# Altium schematic sheet Conn.SchDoc(Conn)
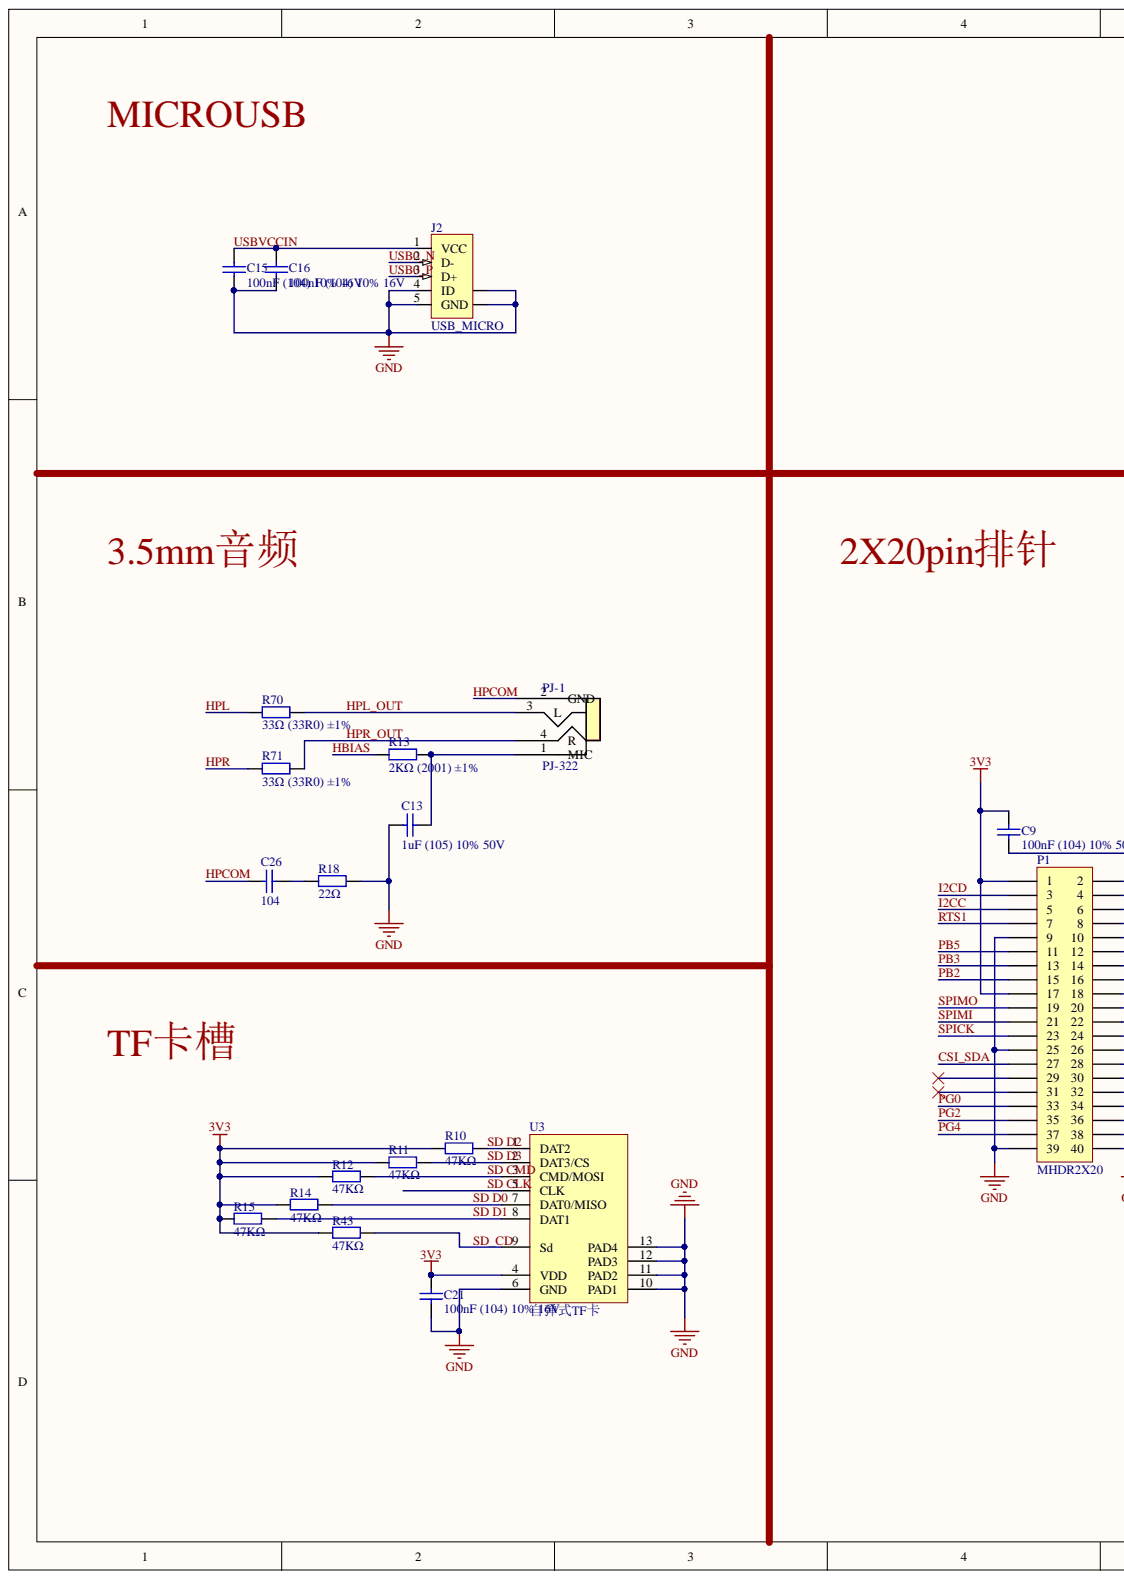
[diagram p0: Conn.SchDoc, left]
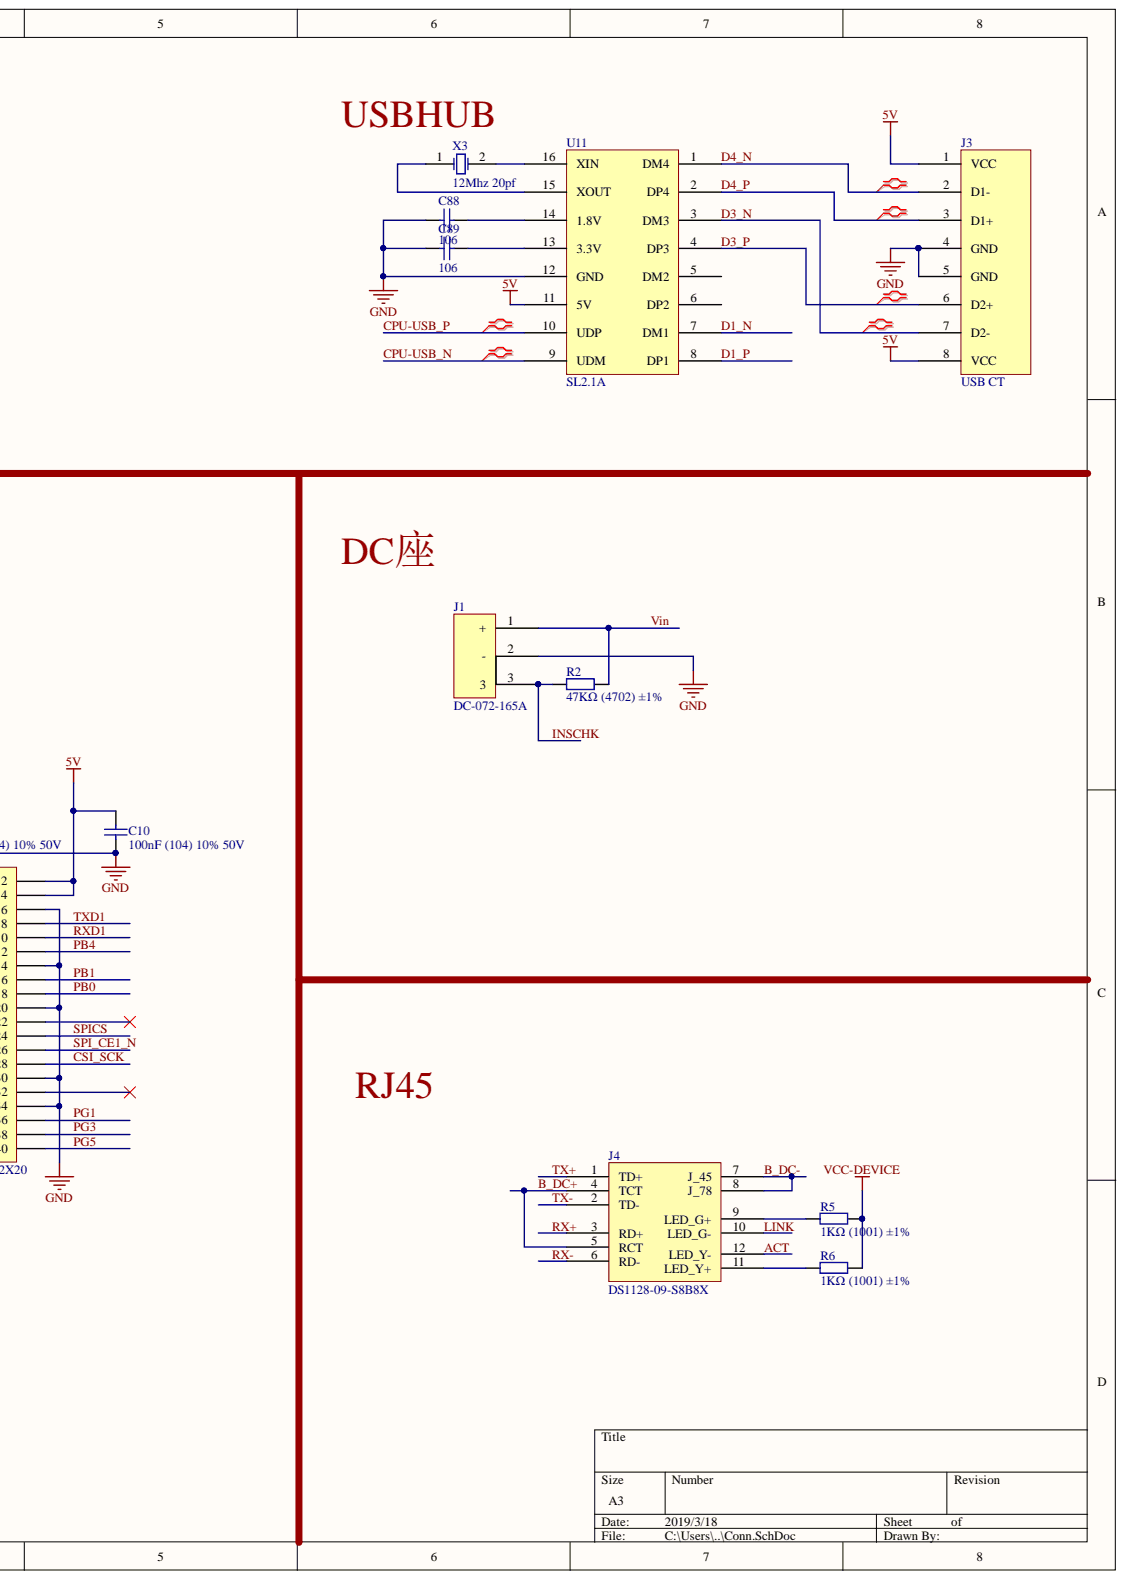
[diagram p1: Conn.SchDoc, right]

## Parts
C9  100nF (104) 10% 50V  [0402_C]
C10  100nF (104) 10% 50V  [0402_C]
C13  1uF (105) 10% 50V  [0603_C]
C15  100nF (104) 10% 16V  [0402_C]
C16  100nF (104) 10% 16V  [0402_C]
C21  100nF (104) 10% 16V  [0402_C]
C26  104  [0402_C]
C88  106  [0402_C]
C89  106  [0402_C]
J1  DC-072-165A  [DC-072-165A]
J2  USB_MICRO  [USB_MICRO1]
J3  USB CT  [USB AF]
J4  DS1128-09-S8B8X  [HR911105A]
P1  MHDR2X20  [HDR2X20]
PJ-1  PJ-322  [PJ322]
R2  47KΩ (4702) ±1%  [0402_R]
R5  1KΩ (1001) ±1%  [0402_R]
R6  1KΩ (1001) ±1%  [0402_R]
R10  47KΩ  [0402_R]
R11  47KΩ  [0402_R]
R12  47KΩ  [0402_R]
R13  2KΩ (2001) ±1%  [0402_R]
R14  47KΩ  [0402_R]
R15  47KΩ  [0402_R]
R18  22Ω  [0402_R]
R43  47KΩ  [0402_R]
R70  33Ω (33R0) ±1%  [0603_R]
R71  33Ω (33R0) ±1%  [0603_R]
U3  自弹式TF卡  [TF_self-eject N]
U11  SL2.1A  [SOP-16_150mil]
X3  12Mhz 20pf  [SMD-5032_2P]

## Nets
3V3: C9.2 C21.2 P1.1 P1.17 R10.1 R11.2 R12.2 R14.2 R15.2 R43.2 U3.4(VDD)
5V: C10.2 J3.1(VCC) J3.8(VCC) P1.2 P1.4 U11.11(5V)
GND: C9.1 C10.1 C13.1 C15.1 C16.1 C21.1 C88.1 C89.1 J1.2 J2.4(ID) J2.5 J2.6(GND) J2.7 J3.4(GND) J3.5(GND) P1.6 P1.9 P1.14 P1.20 P1.25 P1.30 P1.34 P1.39 R18.2 U3.6(GND) U3.10(PAD1) U3.11(PAD2) U3.12(PAD3) U3.13(PAD4) U11.12(GND)
VCC-DEVICE: R5.2 R6.2
ACT: J4.12(LED_Y-)
B_DC+: J4.4(TCT) J4.5(RCT)
B_DC-: J4.7(J_45) J4.8(J_78)
CPU-USB_N: U11.9(UDM)
CPU-USB_P: U11.10(UDP)
CSI_SCK: P1.28
CSI_SDA: P1.27
D1_N: U11.7(DM1)
D1_P: U11.8(DP1)
D3_N: J3.7(D2-) U11.3(DM3)
D3_P: J3.6(D2+) U11.4(DP3)
D4_N: J3.2(D1-) U11.1(DM4)
D4_P: J3.3(D1+) U11.2(DP4)
HBIAS: R13.1
HPCOM: C26.2 PJ-1.2(GND)
HPL: R70.1
HPL_OUT: PJ-1.3(L) R70.2
HPR: R71.1
HPR_OUT: PJ-1.4(R) R71.2
I2CC: P1.5
I2CD: P1.3
INSCHK: J1.3 R2.2
LINK: J4.10(LED_G-)
PB0: P1.18
PB1: P1.16
PB2: P1.15
PB3: P1.13
PB4: P1.12
PB5: P1.11
PG0: P1.33
PG1: P1.36
PG2: P1.35
PG3: P1.38
PG4: P1.37
PG5: P1.40
RTS1: P1.7
RX+: J4.3(RD+)
RX-: J4.6(RD-)
RXD1: P1.10
SD CLK: U3.5(CLK)
SD CMD: R12.1 U3.3(CMD/MOSI)
SD D0: R14.1 U3.7(DAT0/MISO)
SD D1: R15.1 U3.8(DAT1)
SD D2: R10.2 U3.1(DAT2)
SD D3: R11.1 U3.2(DAT3/CS)
SD_CD: R43.1 U3.9(Sd)
SPI_CE1_N: P1.26
SPICK: P1.23
SPICS: P1.24
SPIMI: P1.21
SPIMO: P1.19
TX+: J4.1(TD+)
TX-: J4.2(TD-)
TXD1: P1.8
USB0_N: J2.2(D-)
USB0_P: J2.3(D+)
USBVCCIN: C15.2 C16.2 J2.1(VCC)
Vin: J1.1 R2.1
NetC13_2: C13.2 PJ-1.1(MIC) R13.2
NetC26_1: C26.1 R18.1
NetC88_2: C88.2 U11.14(1.8V)
NetC89_2: C89.2 U11.13(3.3V)
NetJ4_9: J4.9(LED_G+) R5.1
NetJ4_11: J4.11(LED_Y+) R6.1
NetU11_15: U11.15(XOUT) X3.1
NetU11_16: U11.16(XIN) X3.2

Not connected: P1 22 29 31 32; U11 5(DM2) 6(DP2)

## Library data of the parts
C9 C10 C26 = 0603 100nF (104) 10% 50V: 贴片电容; SuppliersPartNumber: C14663; Supplier: LC; Package: 0603; Library Name: Cap.SchLib; ComponentLink1URL: http://www.szlcsc.com/product/details_15331.html; ComponentLink1Description: 供应商链接; Component Kind: Standard
C13 = 0603 1uF (105) 10% 50V: 贴片电容; SuppliersPartNumber: C15849; Supplier: LC; Package: 0603; Library Name: Miscellaneous Devices LC.IntLib; ComponentLink1URL: http://www.szlcsc.com/product/details_16531.html; ComponentLink1Description: 供应商链接; Component Kind: Standard
C15 C16 C21 = 0402 100nF (104) 10% 16V: 贴片电容; SuppliersPartNumber: C1525; Supplier: LC; Package: 0402; Library Name: Miscellaneous Devices LC.IntLib; ComponentLink1URL: http://www.szlcsc.com/product/details_1877.html; ComponentLink1Description: 供应商链接; Component Kind: Standard
C88 C89 = 0402 10uF (106) 20% 6.3V: 贴片电容; SuppliersPartNumber: C15525; Supplier: LC; Package: 0402; Library Name: Miscellaneous Devices LC.IntLib; ComponentLink1URL: http://www.szlcsc.com/product/details_16204.html; ComponentLink1Description: 供应商链接; Component Kind: Standard
J1 = DC-072-165A: C16214; Library Name: V3SDV.SchLib; Component Kind: Standard
J2 = USB_MICRO: C10418; Library Name: Zero.IntLib; Component Kind: Standard
J3 = USB CT: C39470; Library Name: V3SDV.SchLib; Component Kind: Standard
J4 = HLJ-6115ANL: C86578; Library Name: SmallBox.SCHLIB; Component Kind: Standard
P1 = MHDR2X20: Header, 20-Pin, Dual row; Signal Integrity: Connector; Library Name: Miscellaneous Connectors.IntLib; LatestRevisionNote: Re-released for DXP Platform.; LatestRevisionDate: 17-Jul-2002; Component Kind: Standard; Publisher: Altium Limited
PJ-1 = PJ-322: 3.5mm音频连接器; Library Name: V3SDV.SchLib; Component Kind: Standard
R2 R10-R12 R14 R15 R43 = 0402 47KΩ (4702) 1%: 贴片电阻; SuppliersPartNumber: C25792; Supplier: LC; Package: 0402; Library Name: Miscellaneous Devices LC.IntLib; ComponentLink1URL: http://www.szlcsc.com/product/details_26535.html; ComponentLink1Description: 供应商链接; Component Kind: Standard
R5 R6 = 0603 1KΩ (1001) 1%: 贴片电阻; SuppliersPartNumber: C21190; Supplier: LC; Package: 0603; Library Name: RES.SchLib; ComponentLink1URL: http://www.szlcsc.com/product/details_21904.html; ComponentLink1Description: 供应商链接; Component Kind: Standard
R13 = 0402 2.2KΩ (2201) 1%: 贴片电阻; Supplier: LC; Package: 0402; Library Name: Miscellaneous Devices LC.IntLib; ComponentLink1URL: http://www.szlcsc.com/product/details_26622.html; ComponentLink1Description: 供应商链接; Component Kind: Standard
R18 = 0603 22Ω (22R0) 1%: 贴片电阻; SuppliersPartNumber: C23345; Supplier: LC; Package: 0603; Library Name: RES.SchLib; ComponentLink1URL: http://www.szlcsc.com/product/details_24078.html; ComponentLink1Description: 供应商链接; Component Kind: Standard
R70 R71 = 0402 33Ω (33R0) 1%: 贴片电阻; SuppliersPartNumber: C25105; Supplier: LC; Package: 0402; Library Name: Miscellaneous Devices LC.IntLib; ComponentLink1URL: http://www.szlcsc.com/product/details_25848.html; ComponentLink1Description: 供应商链接; Component Kind: Standard
U3 = SD_Card: C266620; Library Name: Zero.IntLib; Component Kind: Standard
U11 = SL2.1A: C192893 USB hub; Library Name: V3SDV.SchLib; Component Kind: Standard
X3 = SMD-5032_2P 12M 20pf 30ppm: 贴片晶振; SuppliersPartNumber: C32346; Supplier: LC; Package: SMD-3215_2P; Library Name: V3SDV.SchLib; ComponentLink1URL: https://item.szlcsc.com/123790.html; ComponentLink1Description: 供应商链接; Component Kind: Standard
